# Altium schematic sheet 01.Leonardo_Rev3d.SchDoc(01.Leonardo_Rev3d)
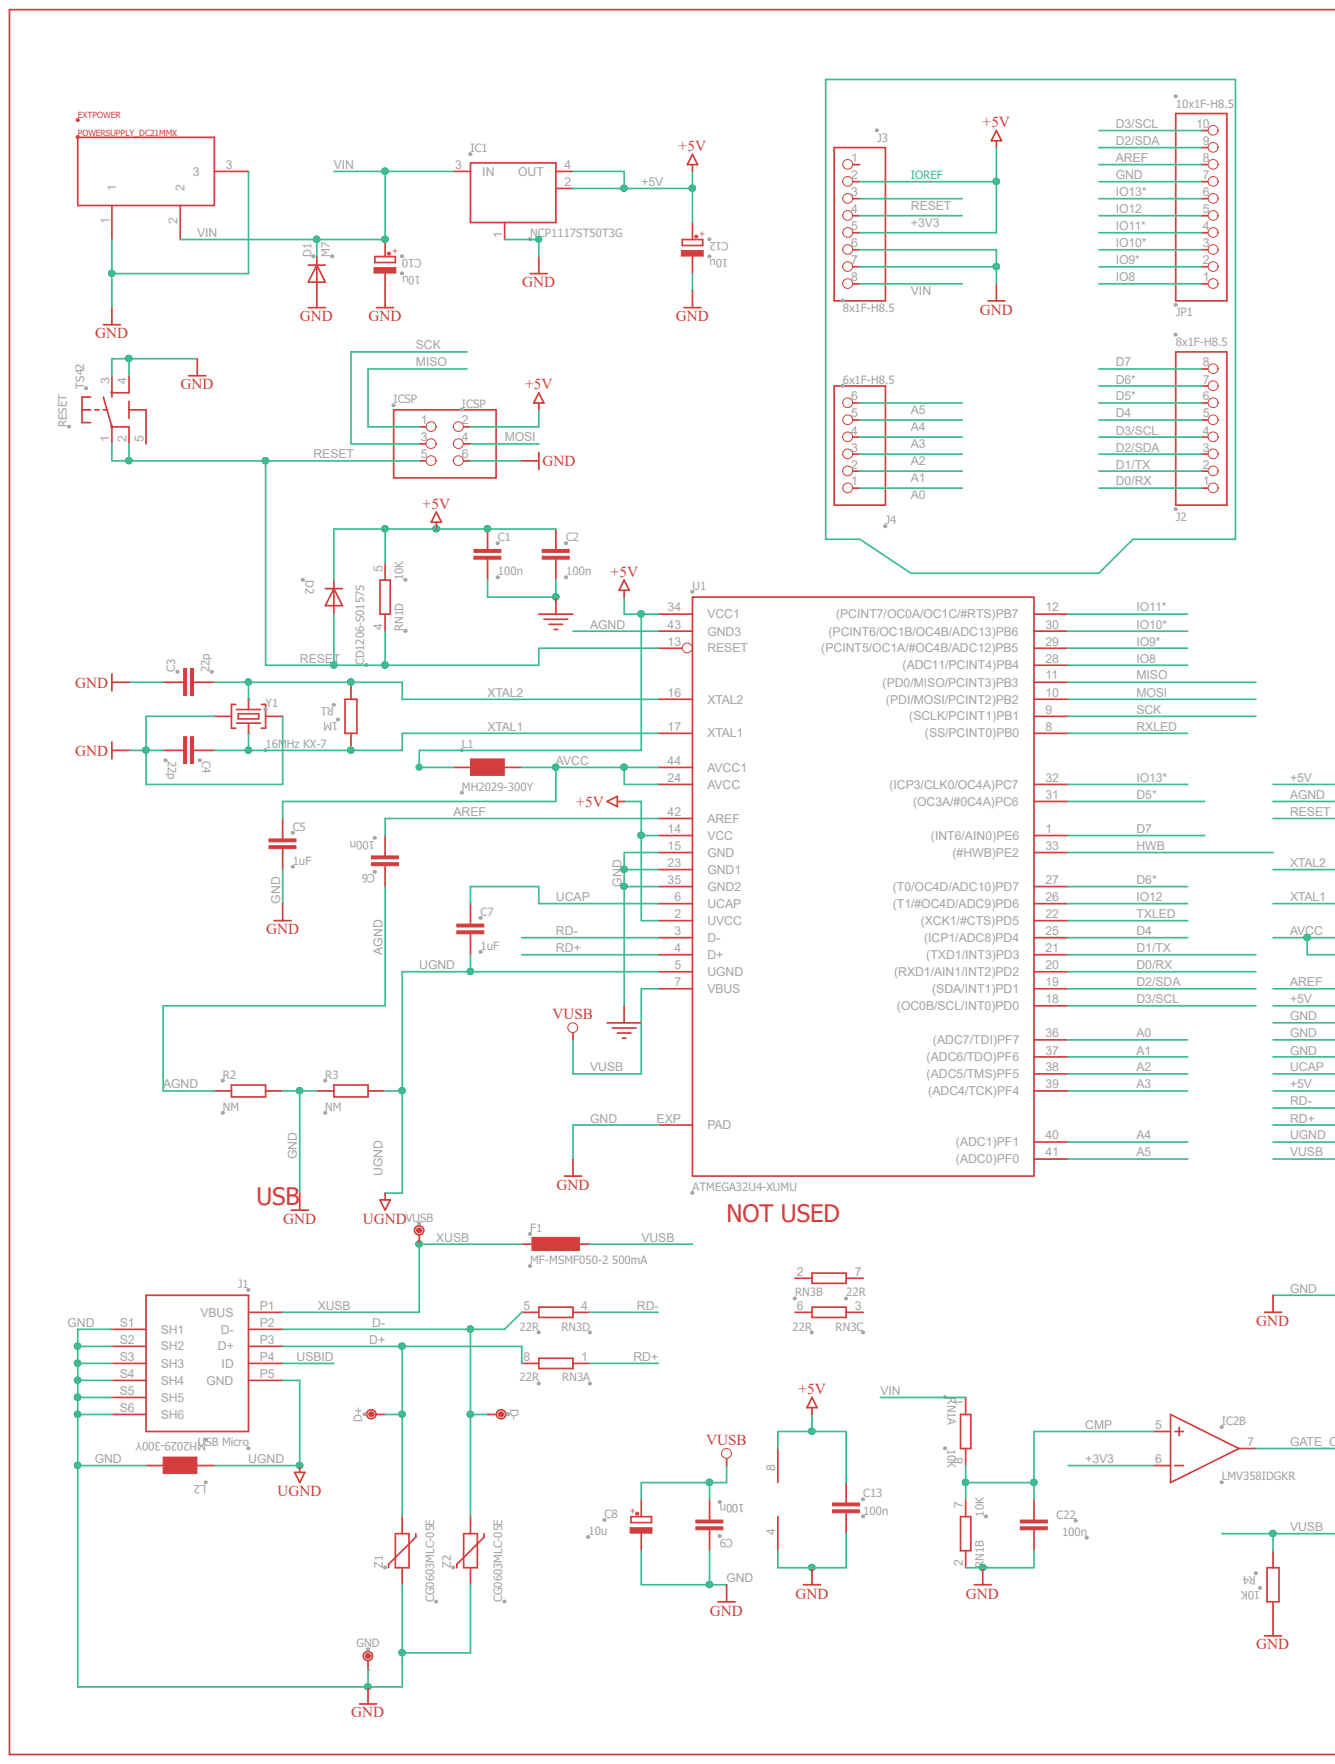
[diagram p0: 01.Leonardo_Rev3d.SchDoc, left]
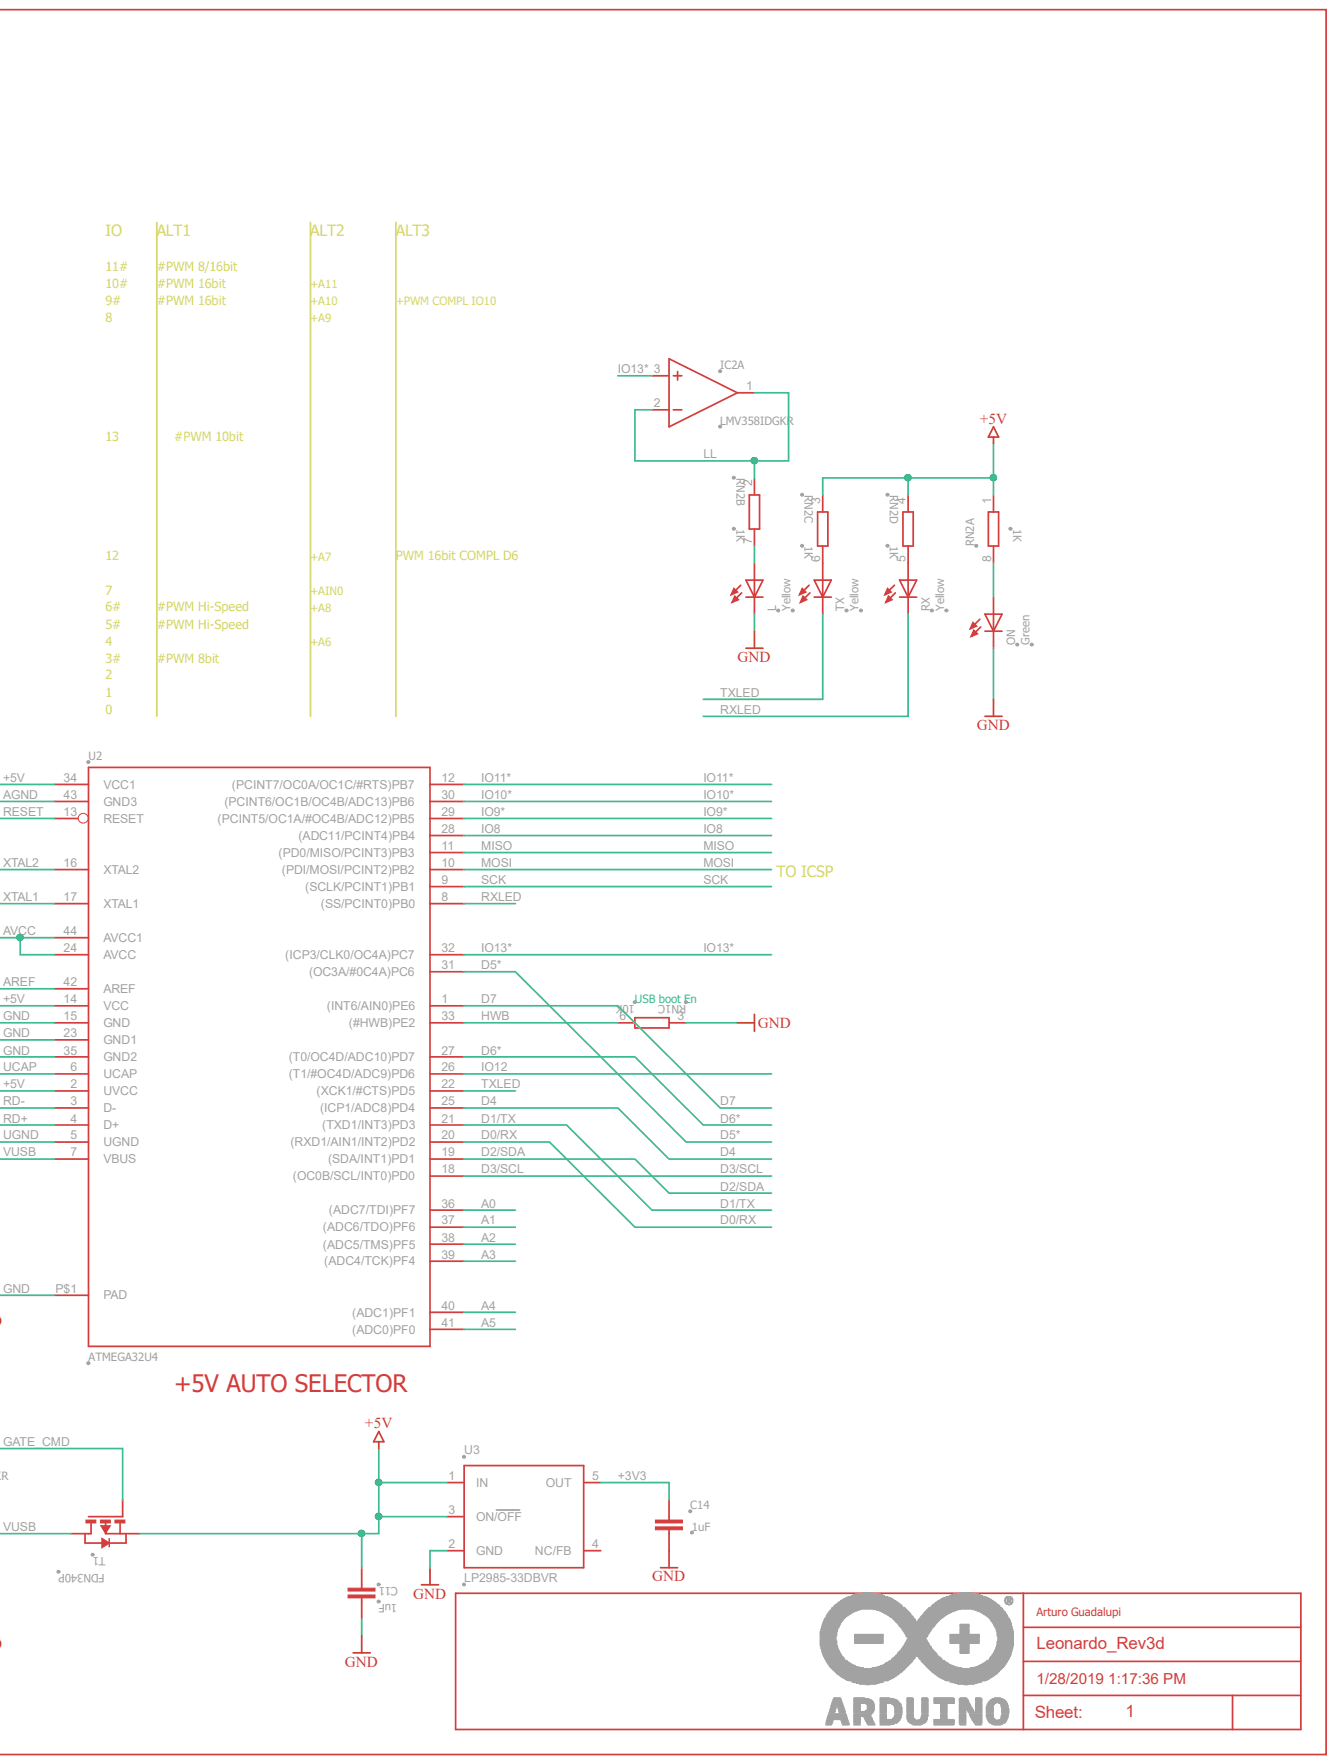
[diagram p1: 01.Leonardo_Rev3d.SchDoc, right]

## Parts
C1  100n
C2  100n
C3  22p
C4  22p
C5  1uF
C6  100n
C7  1uF
C8  10u
C9  100n
C10  10u
C11  1uF
C12  10u
C13  100n
C14  1uF
C22  100n
D1  M7
D2  CD1206-S01575
D+  DNP  (value not drawn)
D-  DNP  (value not drawn)
EXTPOWER  POWERSUPPLY_DC21MMX
F1  MF-MSMF050-2 500mA
GND  DNP  (value not drawn)
IC1  NCP1117ST50T3G
IC2  LMV358IDGKR  (parts A,B,C)
ICSP  ICSP
J1  USB Micro
J2  8x1F-H8.5
J3  8x1F-H8.5
J4  6x1F-H8.5
JP1  10x1F-H8.5
L  Yellow
L1  MH2029-300Y
L2  MH2029-300Y
ON  Green
R1  1M
R2  NM
R3  NM
R4  10K
RESET  TS42
RN1  10K  (parts A,B,C,D)
RN2  1K  (parts A,B,C,D)
RN3  22R  (parts A,B,C,D)
RX  Yellow
T1  FDN340P
TX  Yellow
U1  ATMEGA32U4-XUMU
U2  ATMEGA32U4
U3  LP2985-33DBVR
VUSB  DNP  (value not drawn)
Y1  16MHz KX-7
Z1  CG0603MLC-05E
Z2  CG0603MLC-05E

## Nets
+3V3: C14.2 IC2.6 J3.4 U3.5(OUT)
+5V: C1.1 C2.1 C11.2 C12.+ C13.1 D2.C IC1.2 IC1.4(OUT) IC2.8 ICSP.2 J3.2 J3.5 L1.1 RN1.5 RN2.1 RN2.3 RN2.4 T1.2 U1.2(UVCC) U1.14(VCC) U1.34(VCC1) U2.2(UVCC) U2.14(VCC) U2.34(VCC1) U3.1(IN) U3.3(ON/OFF)
A0: J4.1 U1.36((ADC7/TDI)PF7) U2.36((ADC7/TDI)PF7)
A1: J4.2 U1.37((ADC6/TDO)PF6) U2.37((ADC6/TDO)PF6)
A2: J4.3 U1.38((ADC5/TMS)PF5) U2.38((ADC5/TMS)PF5)
A3: J4.4 U1.39((ADC4/TCK)PF4) U2.39((ADC4/TCK)PF4)
A4: J4.5 U1.40((ADC1)PF1) U2.40((ADC1)PF1)
A5: J4.6 U1.41((ADC0)PF0) U2.41((ADC0)PF0)
AGND: C6.1 R2.1 U1.43(GND3) U2.43(GND3)
AREF: C6.2 JP1.8 U1.42(AREF) U2.42(AREF)
AVCC: C5.1 L1.2 U1.24(AVCC) U1.44(AVCC1) U2.24(AVCC) U2.44(AVCC1)
CMP: C22.2 IC2.5 RN1.7 RN1.8
D0/RX: J2.1 U1.20((RXD1/AIN1/INT2)PD2) U2.20((RXD1/AIN1/INT2)PD2)
D1/TX: J2.2 U1.21((TXD1/INT3)PD3) U2.21((TXD1/INT3)PD3)
D2/SDA: J2.3 JP1.9 U1.19((SDA/INT1)PD1) U2.19((SDA/INT1)PD1)
D3/SCL: J2.4 JP1.10 U1.18((OC0B/SCL/INT0)PD0) U2.18((OC0B/SCL/INT0)PD0)
D4: J2.5 U1.25((ICP1/ADC8)PD4) U2.25((ICP1/ADC8)PD4)
D5*: J2.6 U1.31((OC3A/#0C4A)PC6) U2.31((OC3A/#0C4A)PC6)
D6*: J2.7 U1.27((T0/OC4D/ADC10)PD7) U2.27((T0/OC4D/ADC10)PD7)
D7: J2.8 U1.1((INT6/AIN0)PE6) U2.1((INT6/AIN0)PE6)
D+: D+.X J1.P3(D+) RN3.8 Z1.1
D-: D-.X J1.P2(D-) RN3.5 Z2.1
GATE_CMD: IC2.7 T1.3
GND: C1.2 C2.2 C3.1 C4.2 C5.2 C8.- C9.1 C10.- C11.1 C12.- C13.2 C14.1 C22.1 D1.A EXTPOWER.1 EXTPOWER.3 GND.X IC1.1 IC2.4 ICSP.6 J1.S1(SH1) J1.S2(SH2) J1.S3(SH3) J1.S4(SH4) J1.S5(SH5) J1.S6(SH6) J3.6 J3.7 JP1.7 L.C L2.2 ON.C R2.2 R3.1 R4.1 RESET.3 RESET.4 RN1.2 RN1.3 U1.15(GND) U1.23(GND1) U1.35(GND2) U1.EXP(PAD) U2.15(GND) U2.23(GND1) U2.35(GND2) U2.P$1(PAD) U3.2(GND) Y1.2 Y1.4 Z1.2 Z2.2
HWB: RN1.6 U1.33((#HWB)PE2) U2.33((#HWB)PE2)
IO8: JP1.1 U1.28((ADC11/PCINT4)PB4) U2.28((ADC11/PCINT4)PB4)
IO9*: JP1.2 U1.29((PCINT5/OC1A/#OC4B/ADC12)PB5) U2.29((PCINT5/OC1A/#OC4B/ADC12)PB5)
IO10*: JP1.3 U1.30((PCINT6/OC1B/OC4B/ADC13)PB6) U2.30((PCINT6/OC1B/OC4B/ADC13)PB6)
IO11*: JP1.4 U1.12((PCINT7/OC0A/OC1C/#RTS)PB7) U2.12((PCINT7/OC0A/OC1C/#RTS)PB7)
IO12: JP1.5 U1.26((T1/#OC4D/ADC9)PD6) U2.26((T1/#OC4D/ADC9)PD6)
IO13*: IC2.3 JP1.6 U1.32((ICP3/CLK0/OC4A)PC7) U2.32((ICP3/CLK0/OC4A)PC7)
LL: IC2.1 IC2.2 RN2.2
MISO: ICSP.1 U1.11((PD0/MISO/PCINT3)PB3) U2.11((PD0/MISO/PCINT3)PB3)
MOSI: ICSP.4 U1.10((PDI/MOSI/PCINT2)PB2) U2.10((PDI/MOSI/PCINT2)PB2)
RD+: RN3.1 U1.4(D+) U2.4(D+)
RD-: RN3.4 U1.3(D-) U2.3(D-)
RESET: D2.A ICSP.5 J3.3 RESET.1 RESET.2 RN1.4 U1.13(RESET) U2.13(RESET)
RXLED: RX.C U1.8((SS/PCINT0)PB0) U2.8((SS/PCINT0)PB0)
SCK: ICSP.3 U1.9((SCLK/PCINT1)PB1) U2.9((SCLK/PCINT1)PB1)
TXLED: TX.C U1.22((XCK1/#CTS)PD5) U2.22((XCK1/#CTS)PD5)
UCAP: C7.1 U1.6(UCAP) U2.6(UCAP)
UGND: C7.2 J1.P5(GND) L2.1 R3.2 U1.5(UGND) U2.5(UGND)
USBID: J1.P4(ID)
VIN: C10.+ D1.C EXTPOWER.2 IC1.3(IN) J3.8 RN1.1
VUSB: C8.+ C9.2 F1.1 R4.2 T1.1 U1.7(VBUS) U2.7(VBUS)
XTAL1: C4.1 R1.1 U1.17(XTAL1) U2.17(XTAL1) Y1.1
XTAL2: C3.2 R1.2 U1.16(XTAL2) U2.16(XTAL2) Y1.3
XUSB: F1.2 J1.P1(VBUS) VUSB.X
NetL_A: L.A RN2.7
NetON_A: ON.A RN2.8
NetRN2_5: RN2.5 RX.A
NetRN2_6: RN2.6 TX.A
N00046:

Not connected: J3 1; RESET 5; RN3 2 3 6 7; U3 4(NC/FB)

## Library data of the parts
C1-C7 C9 C11 C13 C14 C22 = (no symbol name): GateName_1: G$1; SymbolName_1: C-EU; DeviceName: C0603; LibraryName: SmartPrj; DeviceSetName: C-EU
C8 C10 C12 = (no symbol name): GateName_1: G$1; SymbolName_1: CPOL; DeviceName: SMCB; DeviceSetName: CPOL-EU; LibraryName: rcl; +: +
D1 = (no symbol name): GateName_1: G$1; SymbolName_1: D; DeviceName: SMB; DeviceSetName: DIODE-; LibraryName: diode
D2 = (no symbol name): GateName_1: G$1; SymbolName_1: D; DeviceName: MINIMELF; DeviceSetName: DIODE-; LibraryName: diode
D+ D- GND VUSB = (no symbol name): GateName_1: G$1; SymbolName_1: TESTPOINT; DeviceName: TP-1.00MM; DeviceSetName: TP; DATASHEET: DNP; DISTRIBUTOR-LINK: DNP; DISTRIBUTOR-PN: DNP; MANUFACTURER: DNP; MANUFACTURER-PN: DNP; LibraryName: Arduino-utility
EXTPOWER = (no symbol name): GateName_1: G$1; SymbolName_1: POWERSUPPLY_DC21PWR; DeviceName: X; LibraryName: SmartPrj; DeviceSetName: POWERSUPPLY_DC21MM
F1 = (no symbol name): GateName_1: G$1; SymbolName_1: L-EU; DeviceName: L1812; LibraryName: rcl; DeviceSetName: L-EU
IC1 = (no symbol name): GateName_1: G$1; SymbolName_1: VREG-O2; DeviceSetName: MC33269ST*; LibraryName: linear
IC2 = (no symbol name): GateName_1: A; SymbolName_1: OPAMP; GateName_2: B; GateName_3: P; SymbolName_3: PWR+-; DeviceName: MM; DeviceSetName: LMV358?*; SymbolName_2: OPAMP; LibraryName: linear
ICSP = (no symbol name): GateName_1: A; SymbolName_1: PINH2X3; DeviceSetName: PINHD-2X3; LibraryName: SmartPrj
J1 = (no symbol name): MANUFACTURER: FCI; MANUFACTURER-PN: 10118193-0001LF; GateName_1: G$1; SymbolName_1: MYCON2_USB; DeviceSetName: USB-MICRO-LEGACY; LibraryName: Arduino-connectors; DATASHEET: http://media.digikey.com/pdf/Data%20Sheets/FCI%20PDFs/10118193-0001LF_Webpage.PDF; DISTRIBUTOR-LINK: http://www.digikey.it/product-detail/en/10118193-0001LF/609-4616-2-ND/2785388; DISTRIBUTOR-PN: 609-4616-2-ND
J2 J3 = (no symbol name): GateName_1: A; SymbolName_1: PINHD8; DeviceSetName: PINHD-1X8; LibraryName: SmartPrj
J4 = (no symbol name): GateName_1: A; SymbolName_1: PINHD6; DeviceSetName: PINHD-1X6; LibraryName: SmartPrj
JP1 = (no symbol name): GateName_1: A; SymbolName_1: PINHD10; DeviceSetName: PINHD-1X10; LibraryName: SmartPrj
L ON RX TX = (no symbol name): GateName_1: G$1; SymbolName_1: LED; DeviceName: CHIPLED_0805; LibraryName: led; DeviceSetName: LED
L1 L2 = (no symbol name): GateName_1: G$1; SymbolName_1: L; DeviceName: _0805; DeviceSetName: WE-CBF; LibraryName: wuerth-elektronik
R1 R4 = (no symbol name): GateName_1: G$1; SymbolName_1: R-EU; DeviceName: R0603; DeviceSetName: R-EU_; LibraryName: SmartPrj
R2 R3 = (no symbol name): GateName_1: G$1; SymbolName_1: R-EU; DeviceName: R0402; DeviceSetName: R-EU_; LibraryName: SmartPrj
RESET = (no symbol name): GateName_1: G$1; SymbolName_1: ST-MOD_V1.4_TS42; LibraryName: SmartPrj; DeviceSetName: TS42
RN1-RN3 = (no symbol name): GateName_1: A; SymbolName_1: R1NV; GateName_2: B; GateName_3: C; GateName_4: D; DeviceName: CAY16; DeviceSetName: 4R-N; SymbolName_2: R1NV; SymbolName_3: R1NV; SymbolName_4: R1NV; LibraryName: resistor-dil
T1 = (no symbol name): GateName_1: A; SymbolName_1: P_MOSFET; DeviceSetName: PMOSSOT23; LibraryName: zetex
U1 = (no symbol name): GateName_1: G$1; DeviceName: MU; SymbolName_1: ATMEGA32U4; LibraryName: SmartPrj; DeviceSetName: ATMEGA32U4-XU
U2 = (no symbol name): GateName_1: G$1; DeviceName: AU; DeviceSetName: ATMEGA32U4-XU; SymbolName_1: ATMEGA32U4; LibraryName: SmartPrj
U3 = (no symbol name): GateName_1: G$1; SymbolName_1: LP2985-XXDBVR; LibraryName: SmartPrj; DeviceSetName: LP2985-XXDBVR
Y1 = (no symbol name): GateName_1: G$1; SymbolName_1: CRYSTAL-KX7; DeviceSetName: CRYSTAL-3.2-2.5; LibraryName: SmartPrj; 1: 1; 2: 2; 3: 3
Z1 Z2 = (no symbol name): GateName_1: G$1; SymbolName_1: VARISTOR; DeviceName: CN0603; LibraryName: varistor; DeviceSetName: VARISTOR
